# Altium schematic sheet Ethernet.SchDoc(Ethernet)
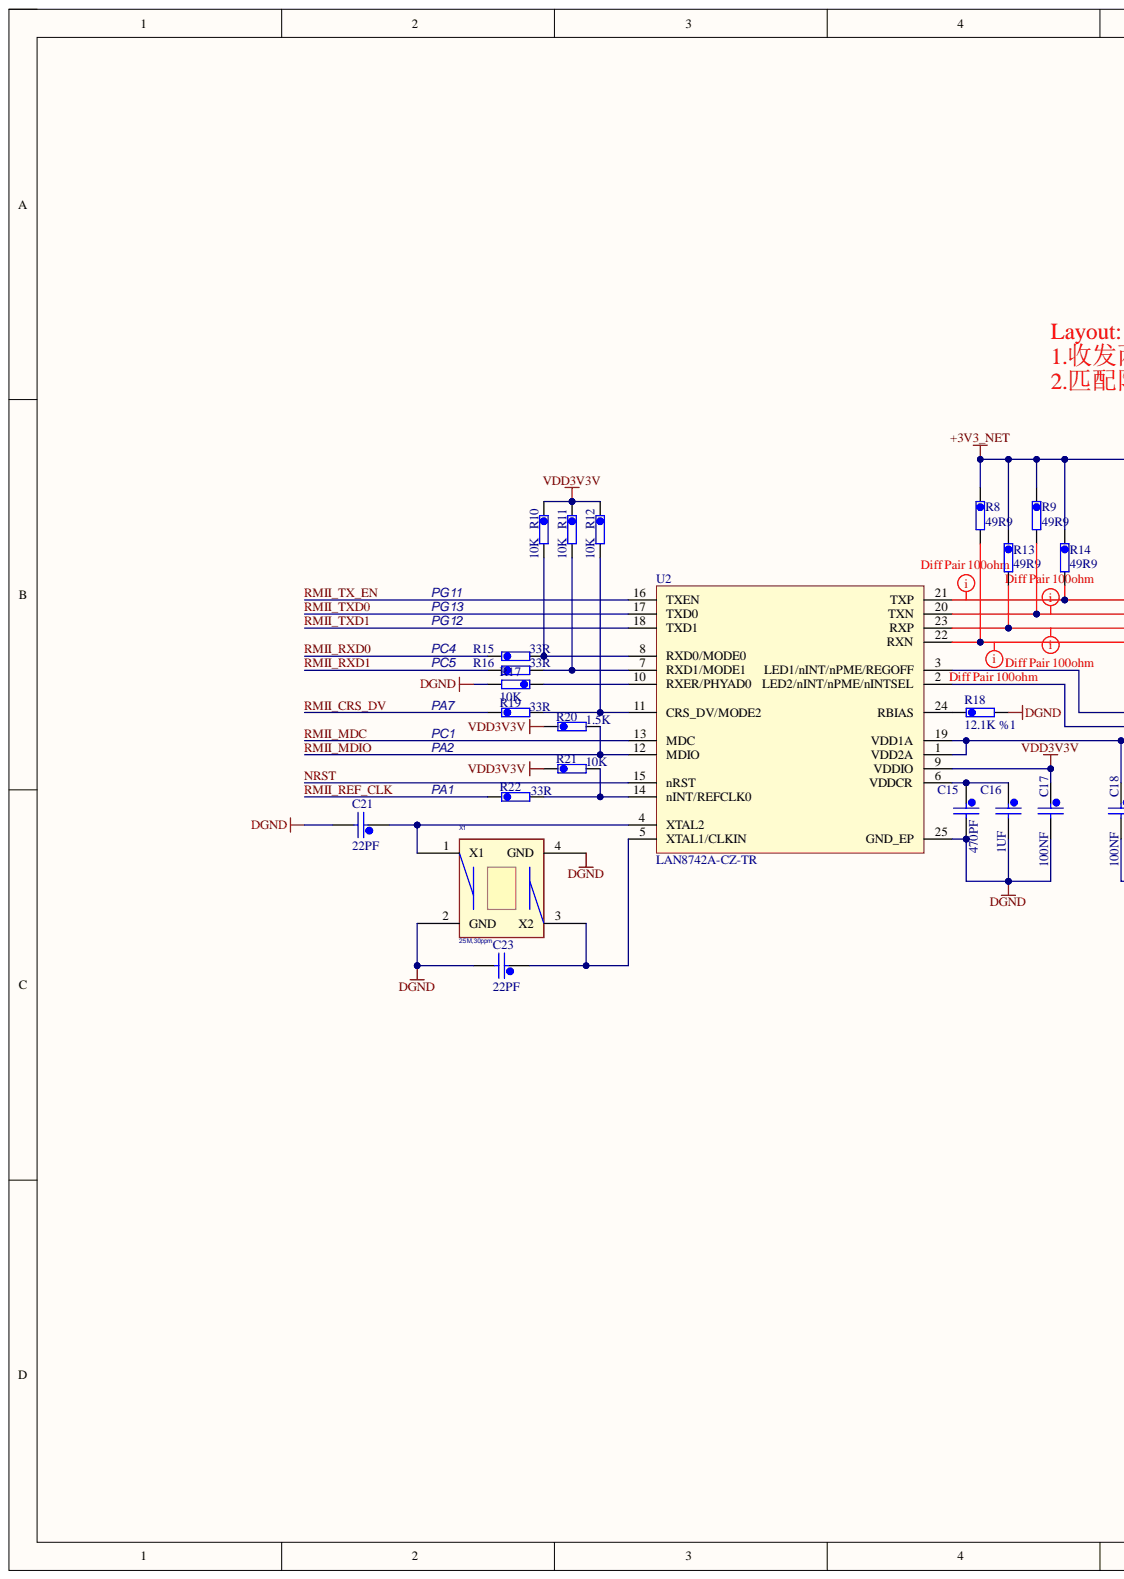
[diagram p0: Ethernet.SchDoc, left]
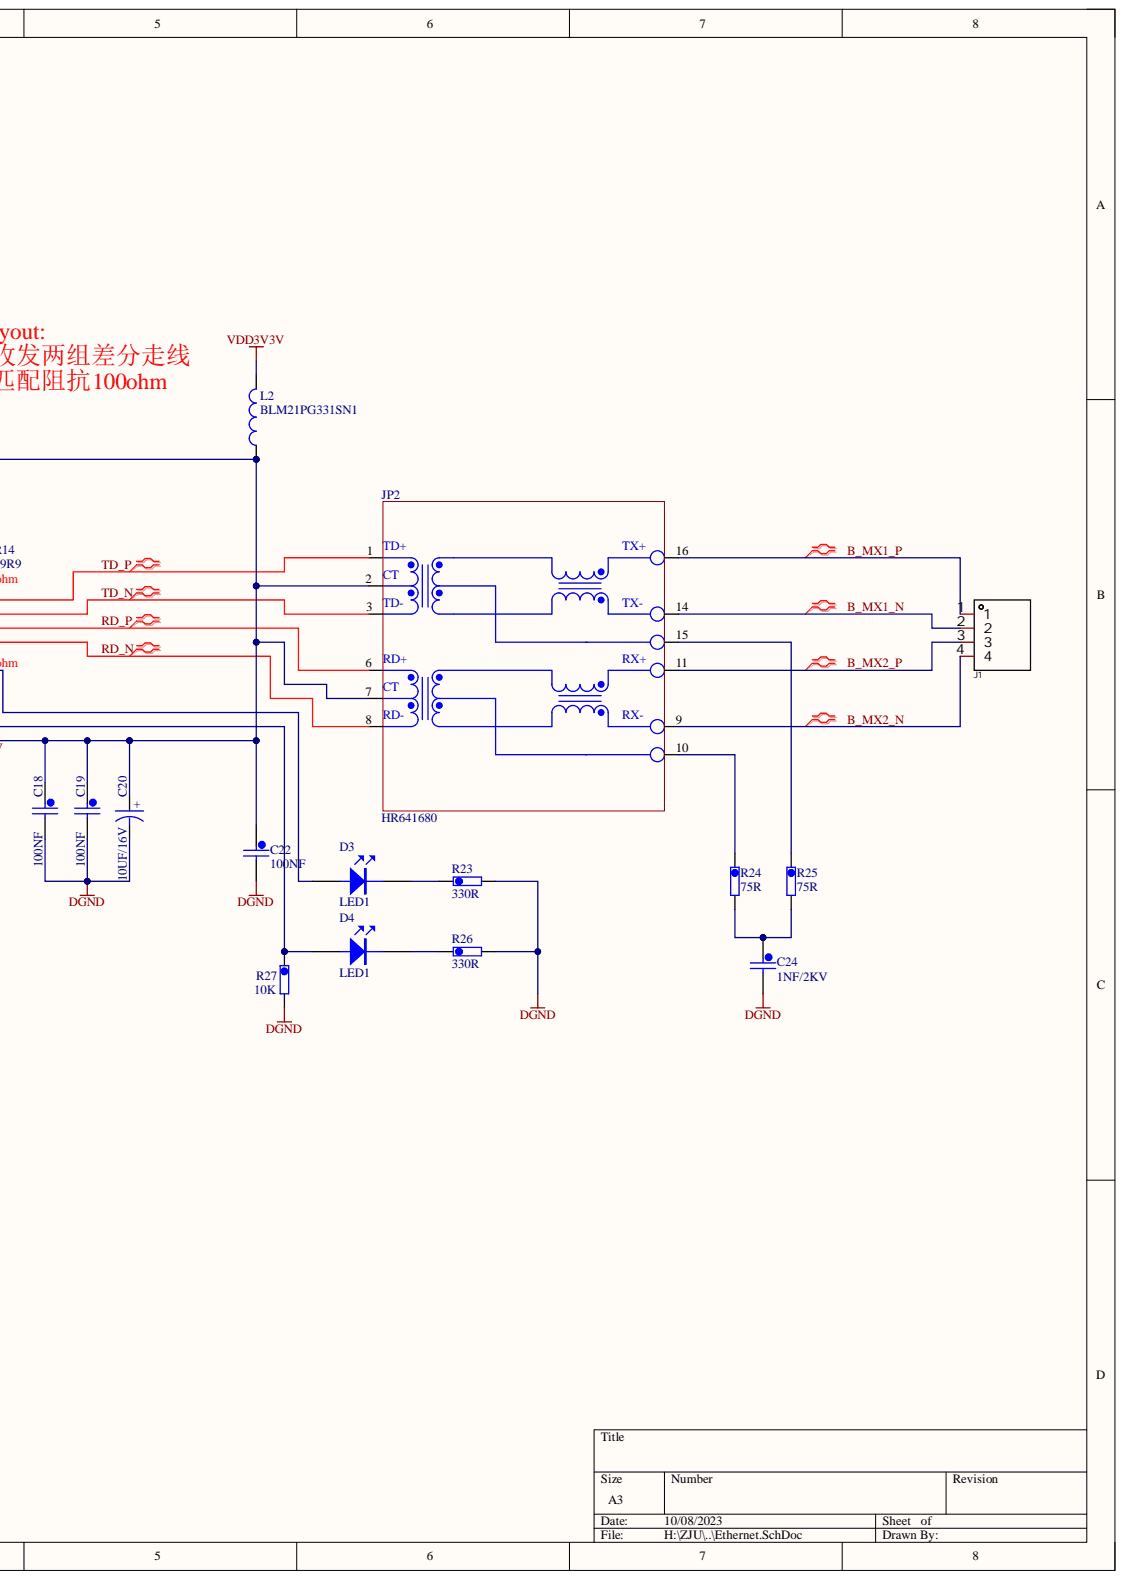
[diagram p1: Ethernet.SchDoc, right]

## Parts
C15  470PF  [0402C]
C16  1UF  [0402C]
C17  100NF  [0402C]
C18  100NF  [0402C]
C19  100NF  [0402C]
C20  10UF/16V  [3528T]
C21  22PF  [0402C]
C22  100NF  [0402C]
C23  22PF  [0402C]
C24  1NF/2KV  [1206]
D3  LED1  [LED-0603]
D4  LED1  [LED-0603]
J1  S4B-PH-K(LF)(SN)  [PH-2.0-4P]  (value not drawn)
JP2  HR641680  [HR641680]
L2  BLM21PG331SN1  [0805R]
R8  49R9  [0402]
R9  49R9  [0402]
R10  10K  [0402]
R11  10K  [0402]
R12  10K  [0402]
R13  49R9  [0402]
R14  49R9  [0402]
R15  33R  [0402]
R16  33R  [0402]
R17  10K  [0402]
R18  12.1K %1  [0402]
R19  33R  [0402]
R20  1.5K  [0402]
R21  10K  [0402]
R22  33R  [0402]
R23  330R  [0402]
R24  75R  [0402]
R25  75R  [0402]
R26  330R  [0402]
R27  10K  [0402]
U2  LAN8742A-CZ-TR  [QFN-24]
X1  25M,30ppm  [XTAL3225]

## Nets
+3V3_NET: C18.1 C19.1 C20.1 C22.1 JP2.2 JP2.7 L2.1 R8.1 R9.1 R13.1 R14.1 U2.1(VDD2A) U2.19(VDD1A)
DGND: C15.2 C16.2 C17.2 C18.2 C19.2 C20.2 C21.2 C22.2 C23.2 C24.2 R17.2 R18.2 R23.2 R26.2 R27.2 U2.25(GND_EP) X1.2(GND) X1.4(GND)
VDD3V3V: C17.1 L2.2 R10.1 R11.1 R12.1 R20.1 R21.1 U2.9(VDDIO)
B_MX1_N: J1.2 JP2.14
B_MX1_P: J1.1 JP2.16
B_MX2_N: J1.4 JP2.9
B_MX2_P: J1.3 JP2.11
NRST: U2.15(nRST)
RD_N: JP2.8 R8.2 U2.22(RXN)
RD_P: JP2.6 R13.2 U2.23(RXP)
RMII_CRS_DV: R19.1
RMII_MDC: U2.13(MDC)
RMII_MDIO: R20.2 U2.12(MDIO)
RMII_REF_CLK: R22.1
RMII_RXD0: R15.1
RMII_RXD1: R16.1
RMII_TX_EN: U2.16(TXEN)
RMII_TXD0: U2.17(TXD0)
RMII_TXD1: U2.18(TXD1)
TD_N: JP2.3 R9.2 U2.20(TXN)
TD_P: JP2.1 R14.2 U2.21(TXP)
NetC15_1: C15.1 C16.1 U2.6(VDDCR)
NetC21_1: C21.1 U2.4(XTAL2) X1.1
NetC23_1: C23.1 U2.5(XTAL1/CLKIN) X1.3(X2)
NetC24_1: C24.1 R24.2 R25.2
NetD3_1: D3.1 U2.3(LED1/nINT/nPME/REGOFF)
NetD3_2: D3.2 R23.1
NetD4_1: D4.1 R27.1 U2.2(LED2/nINT/nPME/nINTSEL)
NetD4_2: D4.2 R26.1
NetJP2_10: JP2.10 R24.1
NetJP2_15: JP2.15 R25.1
NetR10_2: R10.2 R15.2 U2.8(RXD0/MODE0)
NetR11_2: R11.2 R16.2 U2.7(RXD1/MODE1)
NetR12_2: R12.2 R19.2 U2.11(CRS_DV/MODE2)
NetR17_1: R17.1 U2.10(RXER/PHYAD0)
NetR18_1: R18.1 U2.24(RBIAS)
NetR21_2: R21.2 R22.2 U2.14(nINT/REFCLK0)

## Library data of the parts
C15-C19 C21-C24 = CAP: Capacitors; Component Kind: Standard
C20 = CAPACITOR POL: Tan Capacitors; Component Kind: Standard
D3 D4 = LED: Light-Emitting Diode; Component Kind: Standard
J1 = S4B-PH-K(LF)(SN): ph2弯插4p; Manufacturer: JST; Add into BOM: yes; Number of PINs Per Row: 4; Pins Structure: 1x4P; Pitch: 2mm; Reference Series: PH; Component Kind: Standard; Contact Material: 黄铜; Convert to PCB: yes; Datasheet: https://atta.szlcsc.com/upload/public/pdf/source/20180829/C265452_92616666173A3FAF5DFA68F980034548.pdf; Device: S4B-PH-K(LF)(SN); JLCPCB Part Class: Extended Part; LCSC Part Name: S4B-PH-K(LF)(SN); Manufacturer Part: S4B-PH-K(LF)(SN); Mounting Style: -; Name: S4B-PH-K(LF)(SN); Number of Rows: 1; PCB3D: CONN-TH_S4B-PH-K-LF-SN; Row Spacing: -; Supplier: LCSC; Supplier Footprint: 插件,P=2mm; Supplier Part: C265452; Symbol: S4B-PH-K(LF)(SN); Library Name: ROV_CPU.SCHLIB
JP2 = HR641680: 10/100 BASE-T Single Port Transformers Module; Component Kind: Standard; Library Name: ROV.SCHLIB
L2 = Inductor: Inductance; Value: 100uH; Component Kind: Standard; Library Name: Miscellaneous Devices.IntLib; Published: 8-Jun-2000; Revision: July-2002: Re-released for DXP Platform.; Publisher: Altium Limited
R8-R27 = RESA: Resistor; Component Kind: Standard
U2 = LAN8742A-CZ-TR: LAN8742A-CZ-TR - Small Footprint RMII 10/100 Ethernet, Transceiver with HP Auto-MDIX and flexPWR Technology SQFN-24; Manuf_1: MICROCHIP; Component Kind: Standard; Distrib: MICROCHIP; Library Name: MB1137.SCHLIB; Manuf_Part_1: LAN8742A-CZ-TR; Order: LAN8742A-CZ-TR
X1 = DSX321_0: 无源贴片晶振; Component Kind: Standard
